# Altium schematic sheet iF11K.SchDoc
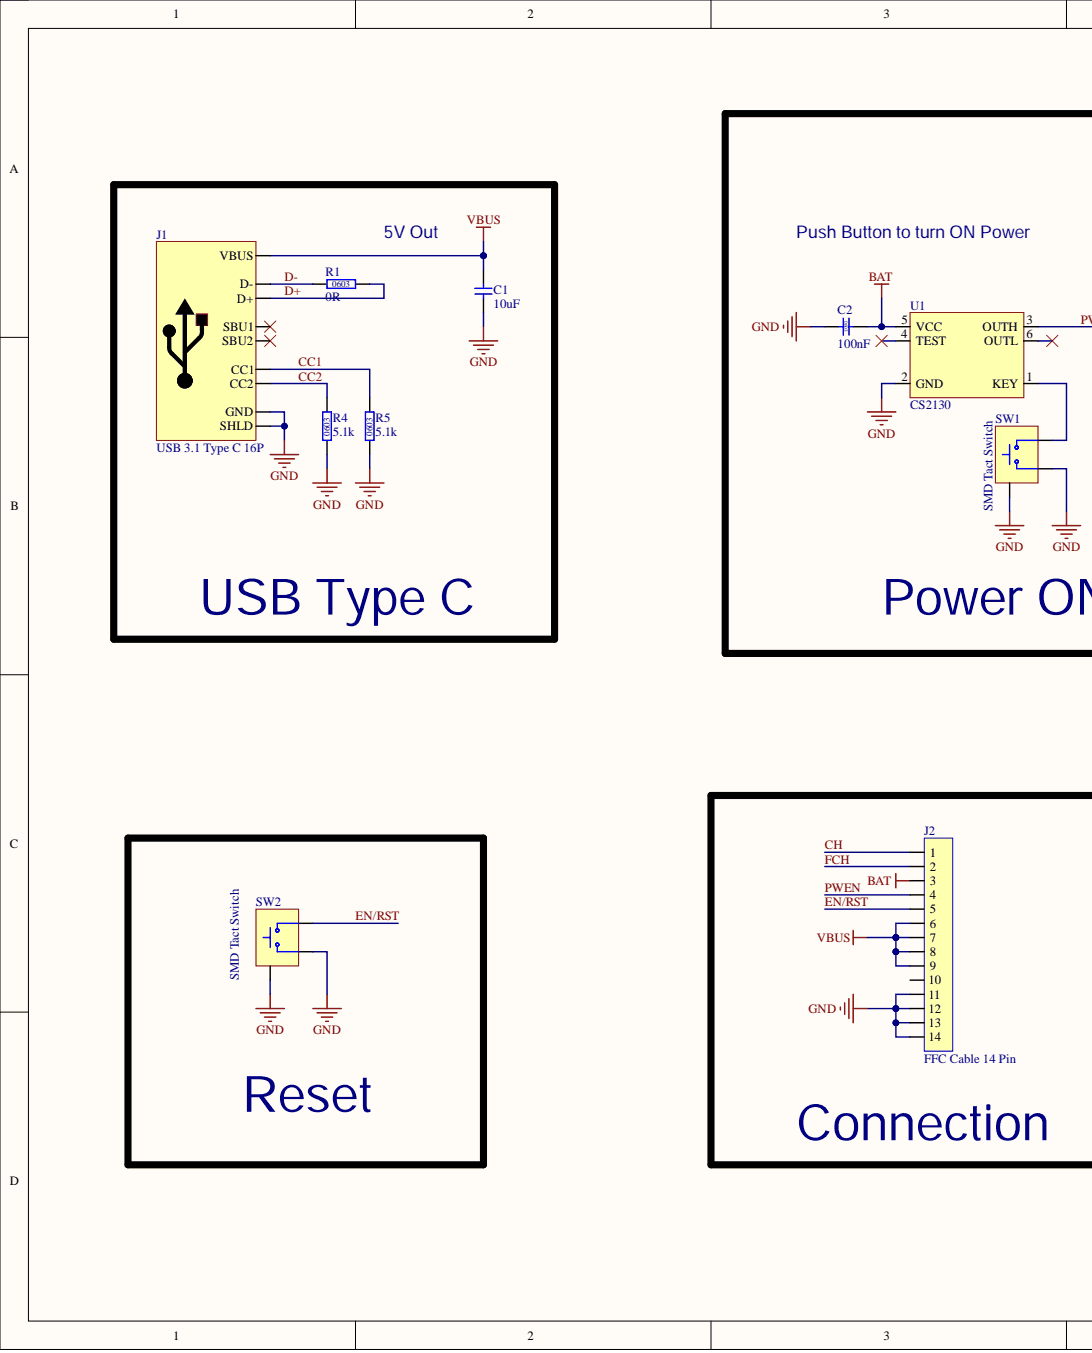
[diagram p0: iF11K.SchDoc, left]
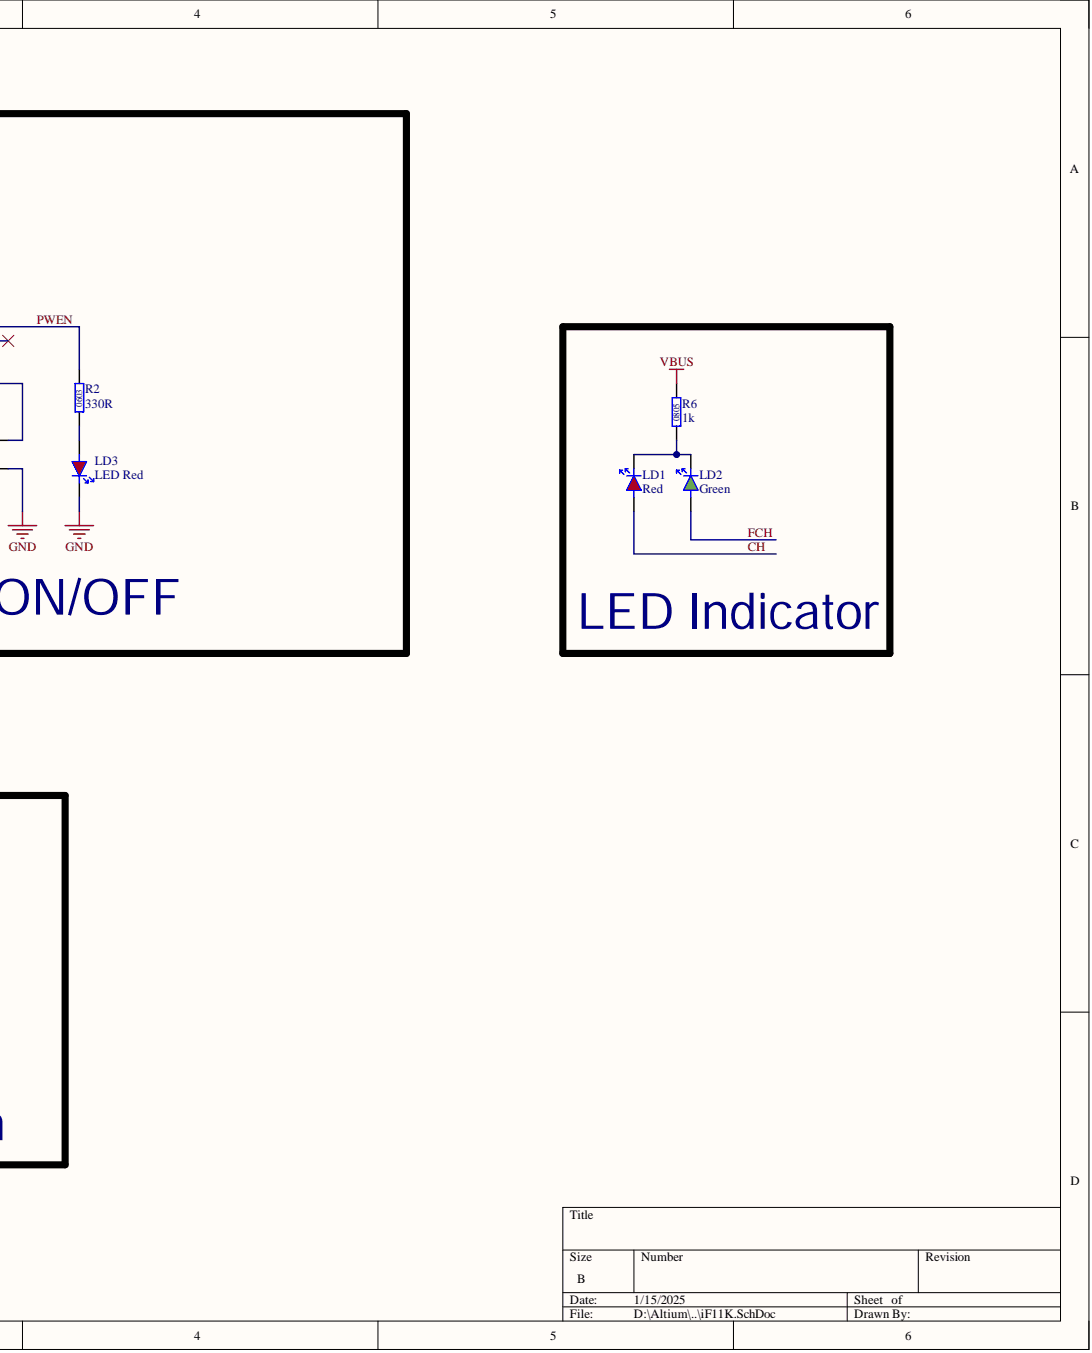
[diagram p1: iF11K.SchDoc, right]

## Parts
C1  10uF  [C0603]
C2  100nF  [C0603]
J1  USB 3.1 Type C 16P  [USBC-16P -Upd]
J2  FFC Cable 14 Pin  [FFC-14-1.25mm]
LD1  Red  [LED-0603-RED]
LD2  Green  [LED-0603-GREEN]
LD3  LED Red  [LED-0603-RED]
R1  0R  [R0603-LD]
R2  330R  [R0603-LD]
R4  5.1k  [R0603-LD]
R5  5.1k  [R0603-LD]
R6  1k  [R0805-LD]
SW1  SMD Tact Switch  [Light button]
SW2  SMD Tact Switch  [Light button]
U1  CS2130  [SOT23-6]

## Nets
BAT: C2.1 J2.3 U1.5(VCC)
GND: C1.1 C2.2 J1.1(GND) J1.10(SHLD) J2.11 J2.12 J2.13 J2.14 LD3.2 R4.1 R5.1 SW1.1 SW1.3 SW2.1 SW2.3 U1.2(GND)
VBUS: C1.2 J1.2(VBUS) J2.6 J2.7 J2.8 J2.9 R6.2
CC1: J1.4(CC1) R5.2
CC2: J1.8(CC2) R4.2
CH: J2.1 LD1.1
D+: J1.6(D+) R1.2
D-: J1.5(D-) R1.1
EN/RST: J2.5 SW2.2
FCH: J2.2 LD2.1
PWEN: J2.4 R2.2 U1.3(OUTH)
NetLD1_2: LD1.2 LD2.2 R6.1
NetLD3_1: LD3.1 R2.1
NetSW1_2: SW1.2 U1.1(KEY)

Not connected: J1 3(SBU2) 7(SBU1); J2 10; U1 4(TEST) 6(OUTL)

## Library data of the parts
C1 = Capacitor: 0603 Package 25V; Component Kind: Standard; Library Name: Capacitors.IntLib; Suppliers: 南京南山半导体有限公司
C2 = C 0603 100nF 50V: 10% 50V; Manufacturer: FENGHUA; Part No: W-T-CA-X703N100K50VNT; Material Name: 贴片电容0603 100NF/50V 10%; Product Code: WP0002721; Component Kind: Standard; Supplier: 南京南山半导体有限公司; Library Name: Rescap.IntLib
J1 = USB-C-16P: TYPE-C 16PIN L8.35; Part No: W-T-CN-TYPE-C 16P; Material Name: USB插座/TYPE-C 16P; Product Code: WP0003709; Component Kind: Standard; Library Name: Connector.IntLib; Supplier: 奥科成电子有限公司
J2 = FFC14P: Component Kind: Standard; Description: FFC Cable 14 Pin; Library Name: Connector.IntLib
LD1 = LED Red: Component Kind: Standard; Library Name: Optoelectronics.SchLib
LD2 = LED Green: Component Kind: Standard; Library Name: Optoelectronics.IntLib
R1 = R 0603 0R: 5%; Manufacturer: 贴片; Part No: W-T-RE-RS03K0RJT; Material Name: 贴片电阻0603 0Ω 5%; Product Code: WP0002766; Component Kind: Standard; Supplier: 南京南山半导体有限公司; Library Name: Rescap.IntLib
R2 = R 0603 330R: 5%; Part No: W-T-RE-RS03K331JT; Material Name: 贴片电阻0603 330Ω 5%; Product Code: WP0003776; Component Kind: Standard; Supplier: 南京南山半导体有限公司; Library Name: Rescap.IntLib
R4 R5 = R 0603 5.1k: 5%; Part No: W-T-RE-RS03K512JT; Material Name: 贴片电阻0603 5.1K 5%; Product Code: WP0002939; Component Kind: Standard; Supplier: 南京南山半导体有限公司; Library Name: Rescap.IntLib
R6 = R 0805 1k: 5%; Part No: W-T-RE-RS05K121JT; Material Name: 贴片电阻0805 120Ω 5%; Product Code: WP0002857; Component Kind: Standard; Supplier: 南京南山半导体有限公司; Library Name: Rescap.IntLib
SW1 SW2 = soft tact switch: Component Kind: Standard; Library Name: Switch.IntLib
U1 = CS2130: Single-button switch IC; Component Kind: Standard; Library Name: mylib2.IntLib
LD3 = LED Red: Component Kind: Standard; Library Name: Optoelectronics.SchLib
